# Altium schematic sheet Sensor-Sheet3.SchDoc(Sensor-Sheet3)
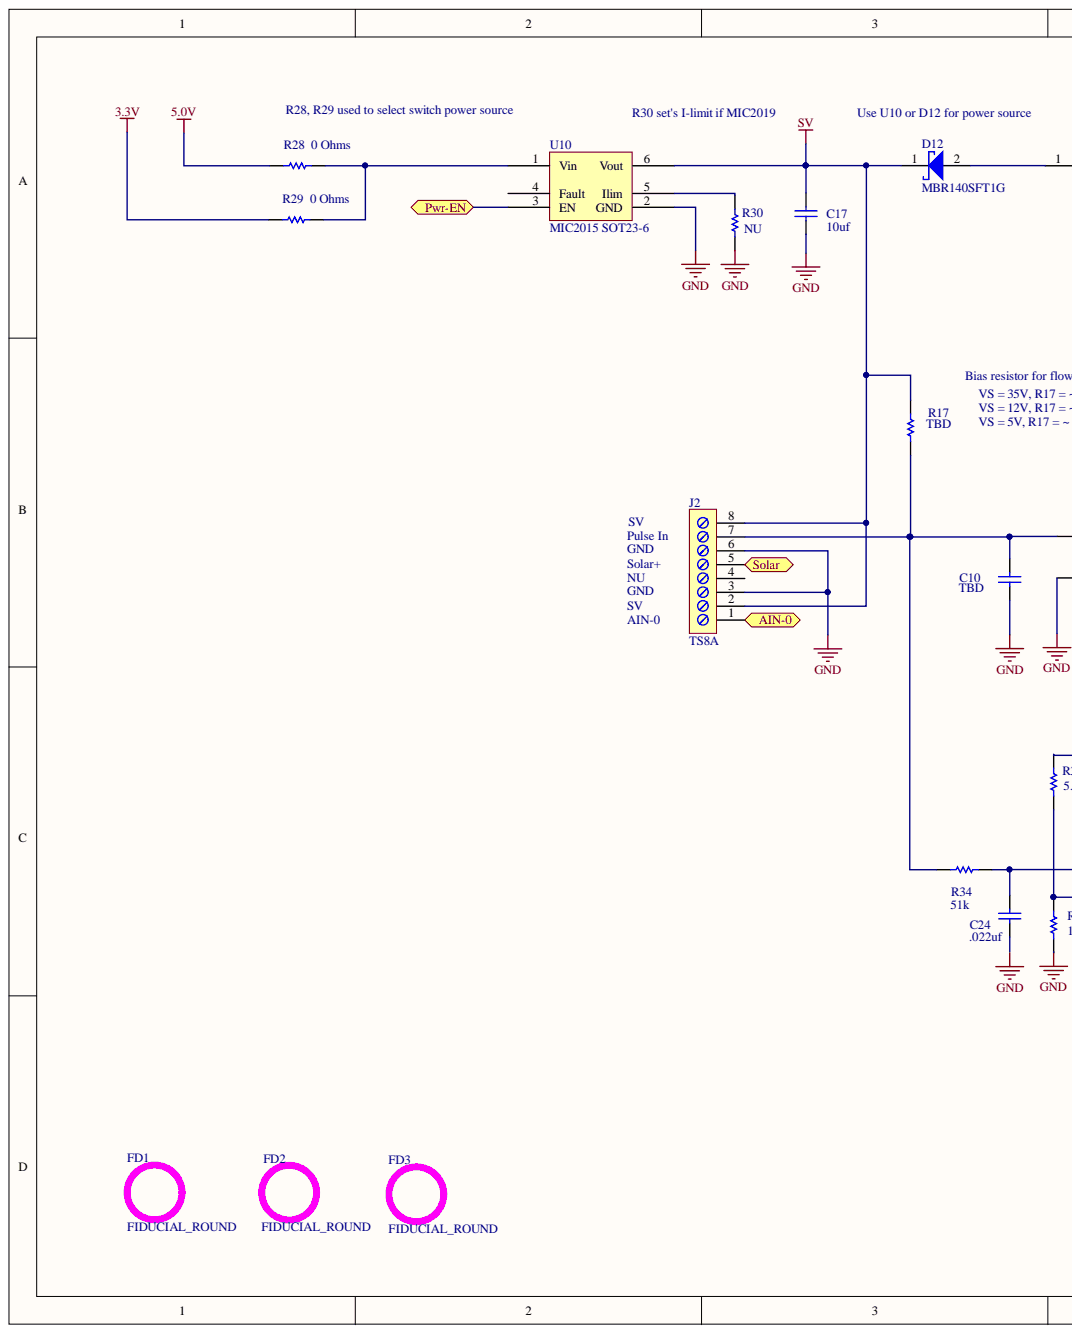
[diagram p0: Sensor-Sheet3.SchDoc, left]
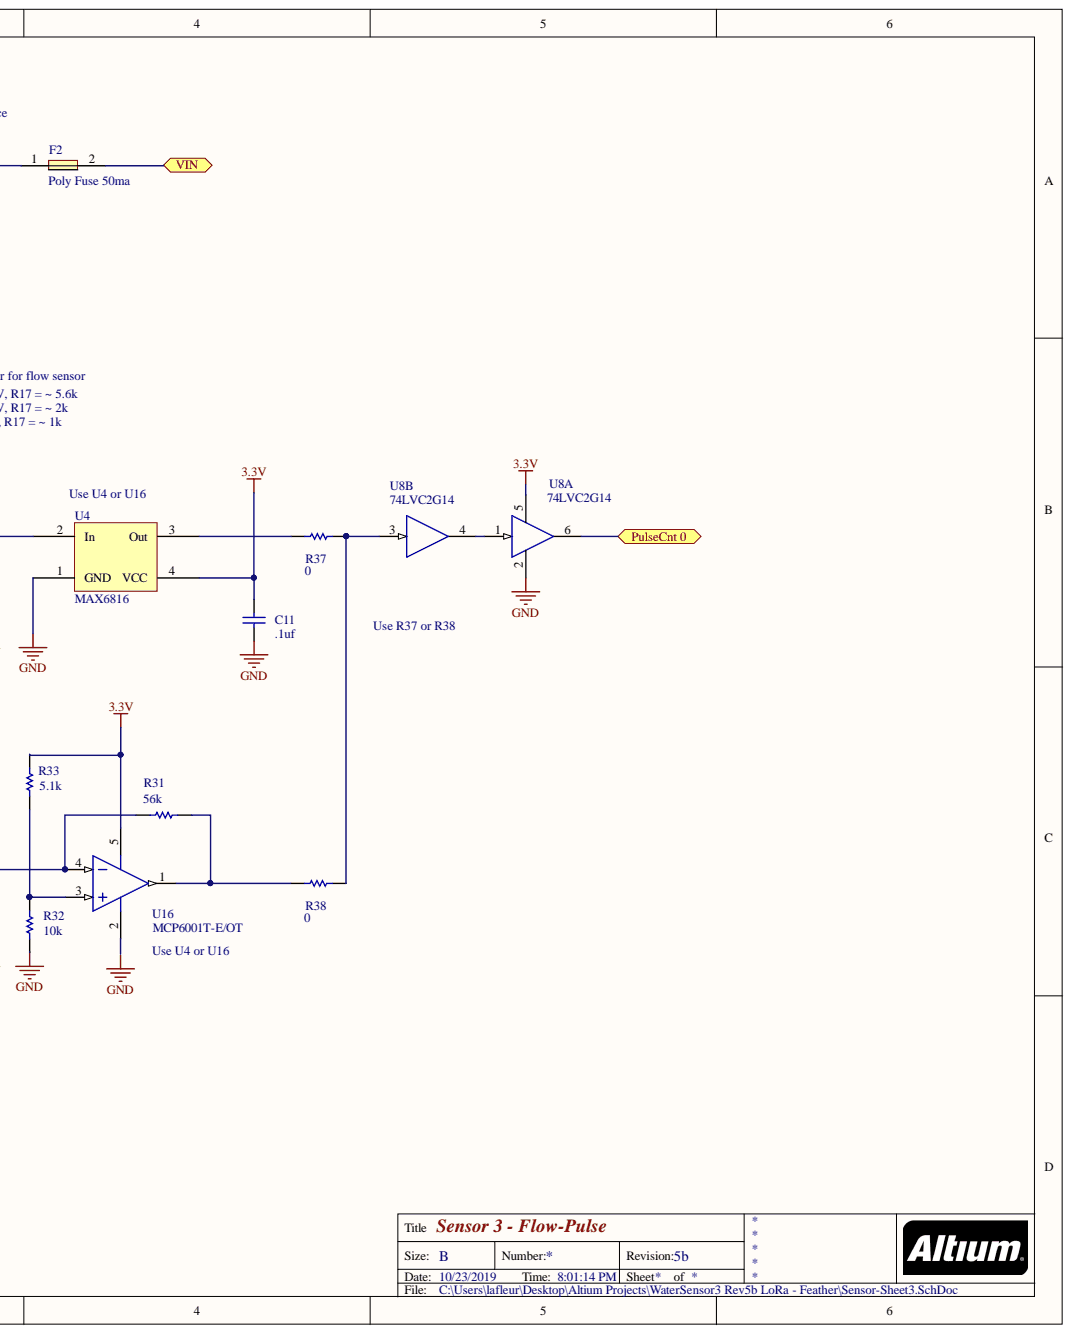
[diagram p1: Sensor-Sheet3.SchDoc, right]

## Parts
C10  TBD  [0805]
C11  .1uf  [0805]
C17  10uf  [C1206]
C24  .022uf  [0805]
D12  MBR140SFT1G  [SOD123FL-2]
F2  Poly Fuse 50ma  [c1206]
FD1  FIDUCIAL_ROUND  [FIDUCIAL_ROUND]  (no BOM)
FD2  FIDUCIAL_ROUND  [FIDUCIAL_ROUND]  (no BOM)
FD3  FIDUCIAL_ROUND  [FIDUCIAL_ROUND]  (no BOM)
J2  TS8A  [TS3.81X8]
R17  TBD  [C1206]
R28  0 Ohms  [6-0805]
R29  0 Ohms  [6-0805]
R30  NU  [6-0805]
R31  56k  [6-0805]
R32  10k  [6-0805]
R33  5.1k  [6-0805]
R34  51k  [6-0805]
R37  0  [6-0805]
R38  0  [6-0805]
U4  MAX6816  [SOT143-4]
U8  74LVC2G14  [sot23-6]  (parts A,B)
U10  MIC2015 SOT23-6  [SOT23-6_N]
U16  MCP6001T-E/OT  [SOT-23-OT5_N]

## Ports
AIN-0, PulseCnt 0, Pwr-EN, Solar, VIN

## Nets
3.3V: C11.1 R29.1 R33.1 U4.4(VCC) U8.5 U16.5
5.0V: R28.1
GND: C10.2 C11.2 C17.2 J2.3 J2.6 R30.1 U4.1(GND) U8.2 U10.2(GND)
SV: C17.1 D12.1 J2.2 J2.8 R17.1 U10.6(Vout)
AIN-0: J2.1 ; port AIN-0
PulseCnt 0: U8.6 ; port PulseCnt 0
Pwr-EN: U10.3(EN) ; port Pwr-EN
Solar: J2.5 ; port Solar
VIN: F2.2 ; port VIN
NetC10_1: C10.1 J2.7 R17.2 R34.2 U4.2(In)
NetC24_1: C24.1 R31.2 R34.1 U16.4
NetC24_2: C24.2
NetD12_2: D12.2 F2.1
NetJ2_4: J2.4
NetR28_2: R28.2 R29.2 U10.1(Vin)
NetR30_2: R30.2 U10.5(Ilim)
NetR31_1: R31.1 R38.2 U16.1
NetR32_1: R32.1 R33.2 U16.3
NetR32_2: R32.2
NetR37_1: R37.1 R38.1 U8.3
NetR37_2: R37.2 U4.3(Out)
NetU8_1: U8.1 U8.4
NetU10_4: U10.4(Fault)
NetU16_2: U16.2

## Library data of the parts
C10 C11 C17 C24 = CAP_1: Capacitor; Fitted: True; Library Name: N2K Project.SCHLIB; Component Kind: Standard
D12 = Diode Schottky: Schottky Diode; Published: 5-Jan-2004; DatasheetDocument: RevB, 21-Aug-2003; Component Kind: Standard; Publisher: Altium Limited; Library Name: Winmor-Demo-R2.IntLib
F2 = Poly Fuse: Library Name: N2K Project.SCHLIB; Description: Poly Fuse; Component Kind: Standard
FD1-FD3 = CMP-0486-00001-2: Fiducial - Round 1.5 mm Top-Bottom; Manufacturer: No Vendor; PartNumber: FIDUCIAL_ROUND; Library Name: Altium Content Vault
J2 = TS8A: Screw Terminal 3.81mm (.15in) 8X; Component Kind: Standard; Library Name: Winmor-Demo-R1.IntLib
R17 R28-R34 R37 R38 = ERJ8EN: Precision Thick Film Chip Resistor, 10 Ohm to 2.2M Ohm Range, 1% Tolerance, 1206 Size, 0.125 W; Published: 17-Dec-2003; PackageReference: 8-1206; PackageDocument: Mar-2003; LatestRevisionNote: Silkscreen size reduced.; LatestRevisionDate: 19-Mar-2004; ComponentLink2URL: http://www.panasonic.com/industrial/components/pdf/AOA0000CE2.pdf; ComponentLink2Description: Datasheet; ComponentLink1URL: http://www.panasonic.com/industrial/components/resistive_products.htm; ComponentLink1Description: Manufacturer Link; Component Kind: Standard; Code_IPC: 3216 [1206]; Publisher: Altium Limited; Library Name: Winmor-Demo-R1.IntLib
U4 = MAX6816: Max6816 Switch Debounce, CMOS; Library Name: WaterSensor_4.SCHLIB; Component Kind: Standard
U8 = 74LVC2G07: Dual OC Driver; Published: 8-Jun-2000; PackageVersion: May-1999; PackageReference: DB014; PackageDescription: SSOP, Plastic; 14 Leads; Body Width 5.3 mm; Pitch 0.65 mm; LatestRevisionNote: Stylized 3D Model Added.; LatestRevisionDate: 04-Mar-2005; DatasheetVersion: Jul-1998; ComponentLink3URL: http://focus.ti.com/lit/ml/msso002e/msso002e.pdf; ComponentLink3Description: Packaging Specification; ComponentLink2URL: http://www-s.ti.com/sc/ds/sn74ahc14.pdf; ComponentLink2Description: Datasheet; ComponentLink1URL: http://www.ti.com/; ComponentLink1Description: Manufacturer Link; Component Kind: Standard; Code_JEDEC: MO-150-AB; Publisher: Altium Limited; Library Name: ARDOP-Modem Rev1_5.SCHLIB
U10 = MIC2015-6: Power Switch, 500ma 6pin; Library Name: WaterSensor V3-5.SCHLIB; Component Kind: Standard
U16 = CMP-0187-00458-2: 1 MHz, Low-Power Operational Amplifier, 5-Pin SOT-23, Extended Temperature, Tape and Reel; RevisionNote: URLs added.; PackageVersion: revBB, Aug-2009; PackageReference: SOT-23-OT5; PackageDescription: 5-Lead Plastic Small Outline Transistor (OT) [SOT-23]; Library Name: Altium Content Vault; DatasheetVersion: revJ, Nov-2009; ComponentLink3URL: http://www.microchip.com/stellent/groups/techpub_sg/documents/packagingspec/en012702.pdf; ComponentLink3Description: Package Specification; ComponentLink2URL: http://ww1.microchip.com/downloads/en/DeviceDoc/21733j.pdf; ComponentLink2Description: Datasheet; ComponentLink1URL: http://www.microchip.com/; ComponentLink1Description: Manufacturer URL; Component Kind: Standard; Code_IPC: SOT23-5
